# Altium schematic sheet sem02_2442hold.SchDoc
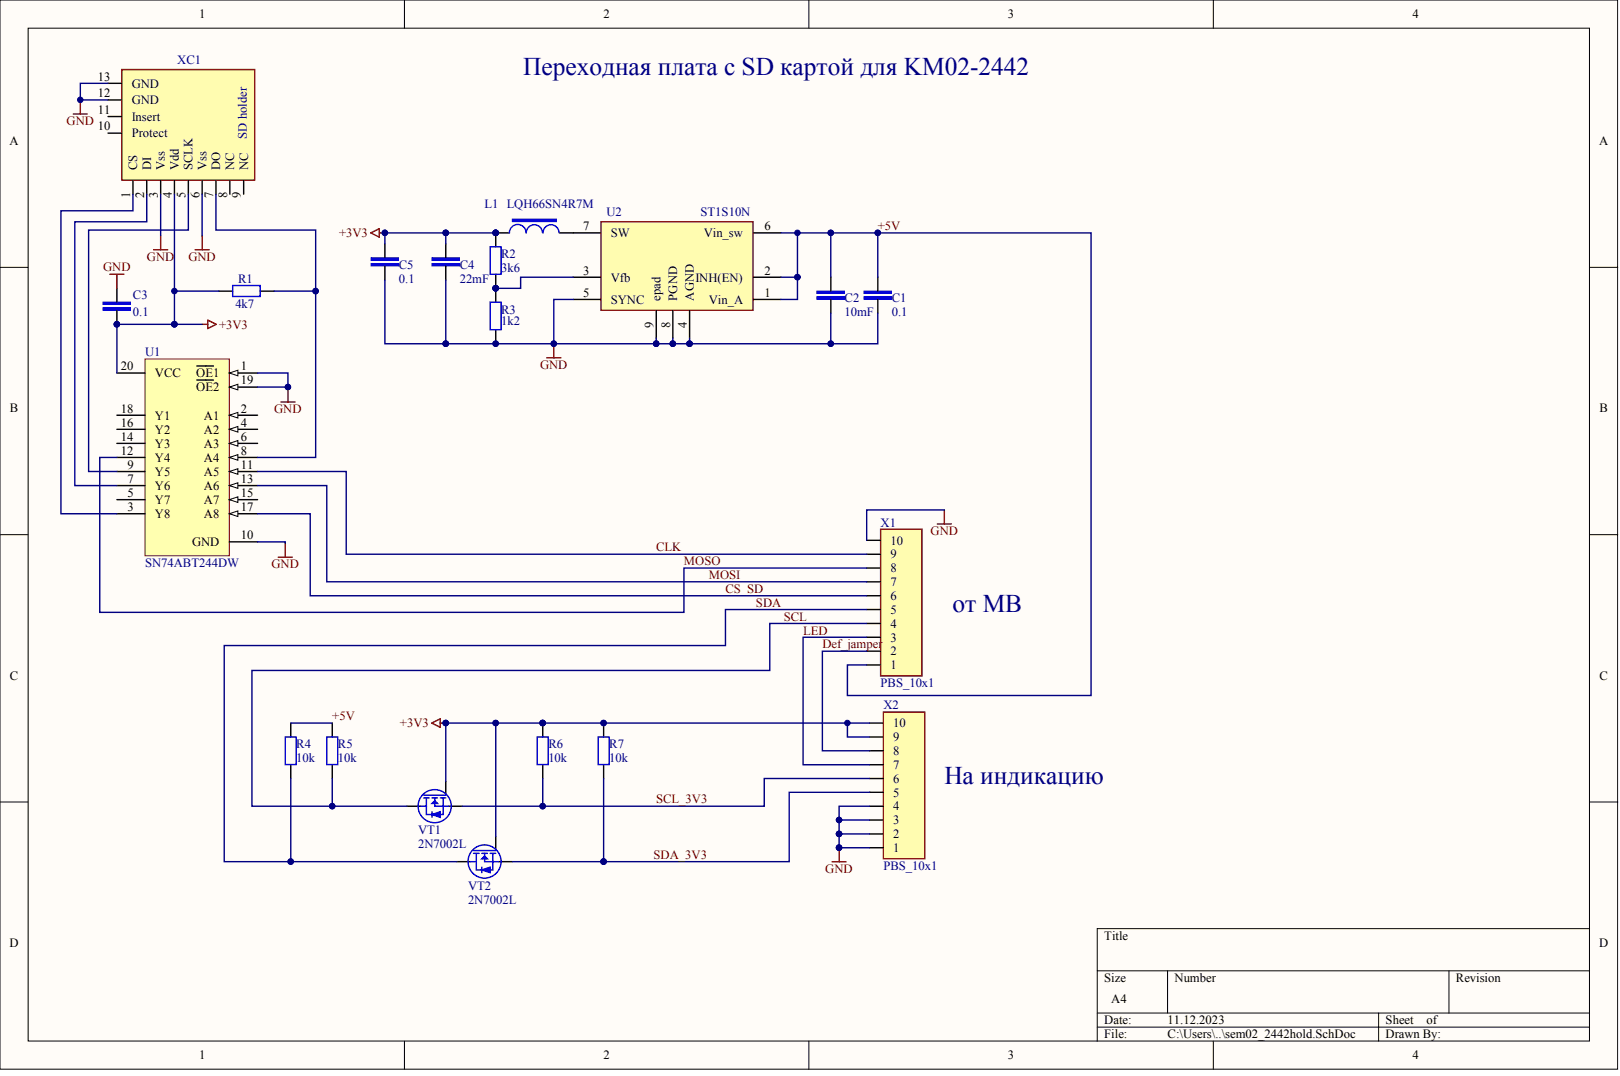
[diagram p0: sem02_2442hold.SchDoc]

## Parts
C1  0.1
C2  10mF
C3  0.1
C4  22mF
C5  0.1
L1  LQH66SN4R7M
R1  4k7
R2  3k6
R3  1k2
R4  10k
R5  10k
R6  10k
R7  10k
U1  SN74ABT244DW
U2  ST1S10N
VT1  2N7002L
VT2  2N7002L
X1  PBS_10x1
X2  PBS_10x1
XC1  SD_card_holder  (value not drawn)

## Nets
+3V3: C3.2 C4.2 C5.1 L1.2 R1.2 R2.1 R6.1 R7.1 U1.20(VCC) VT1.1 VT2.1 X2.9 X2.10 XC1.4(Vdd)
GND: C1.2 C2.1 C3.1 C4.1 C5.2 R3.2 U1.1(OE1) U1.10(GND) U1.19(OE2) U2.4(AGND) U2.5(SYNC) U2.8(PGND) U2.9(epad) X1.10 X2.1 X2.2 X2.3 X2.4 XC1.3(Vss) XC1.6(Vss) XC1.12(GND) XC1.13(GND)
+5V: C1.1 C2.2 R4.1 R5.1 U2.1(Vin_A) U2.2(INH(EN)) U2.6(Vin_sw) X1.1
CLK: U1.11(A5) X1.9
CS_SD: U1.17(A8) X1.6
DEF_JAMPER: X1.2 X2.8
LED: X1.3 X2.7
MOSI: U1.13(A6) X1.7
MOSO: U1.12(Y4) X1.8
SCL: R5.2 VT1.3 X1.4
SCL_3V3: R6.2 VT1.2 X2.6
SDA: R4.2 VT2.3 X1.5
SDA_3V3: R7.2 VT2.2 X2.5
NetL1_1: L1.1 U2.7(SW)
NetR1_1: R1.1 U1.8(A4) XC1.7(DO)
NetR2_2: R2.2 R3.1 U2.3(Vfb)
NetU1_3: U1.3(Y8) XC1.1(CS)
NetU1_7: U1.7(Y6) XC1.2(DI)
NetU1_9: U1.9(Y5) XC1.5(SCLK)

Not connected: U1 2(A1) 4(A2) 5(Y7) 6(A3) 14(Y3) 15(A7) 16(Y2) 18(Y1); XC1 8(NC) 9(NC) 10(Protect) 11(Insert)

## Library data of the parts
U1 = (no symbol name): ComponentAvailability: Obsolete; Published: 8-Jun-2000; LatestRevisionDate: 13-Apr-2006; LatestRevisionNote: IPC-7351 Footprint Added.; DatasheetVersion: Jul-1994; PackageVersion: Mar-2000; PackageReference: DW020; PackageDescription: 20-Pin Plastic Small Outline Integrated Circuit 1.27 mm Pitch; Code_JEDEC: MS-013; Code_IPC: SOIC127P1030-20; ComponentLink1Description: Manufacturer Link; ComponentLink1URL: http://www.ti.com/; ComponentLink2Description: Discontinuation Notice; ComponentLink2URL: http://focus.ti.com/docs/prod/folders/print/sn74abt244.html; Publisher: Altium Limited
